# Altium schematic sheet P770010E05-Xx-SH-4_GNSS.SchDoc(P770010E05-Xx-SH-4_GNSS)
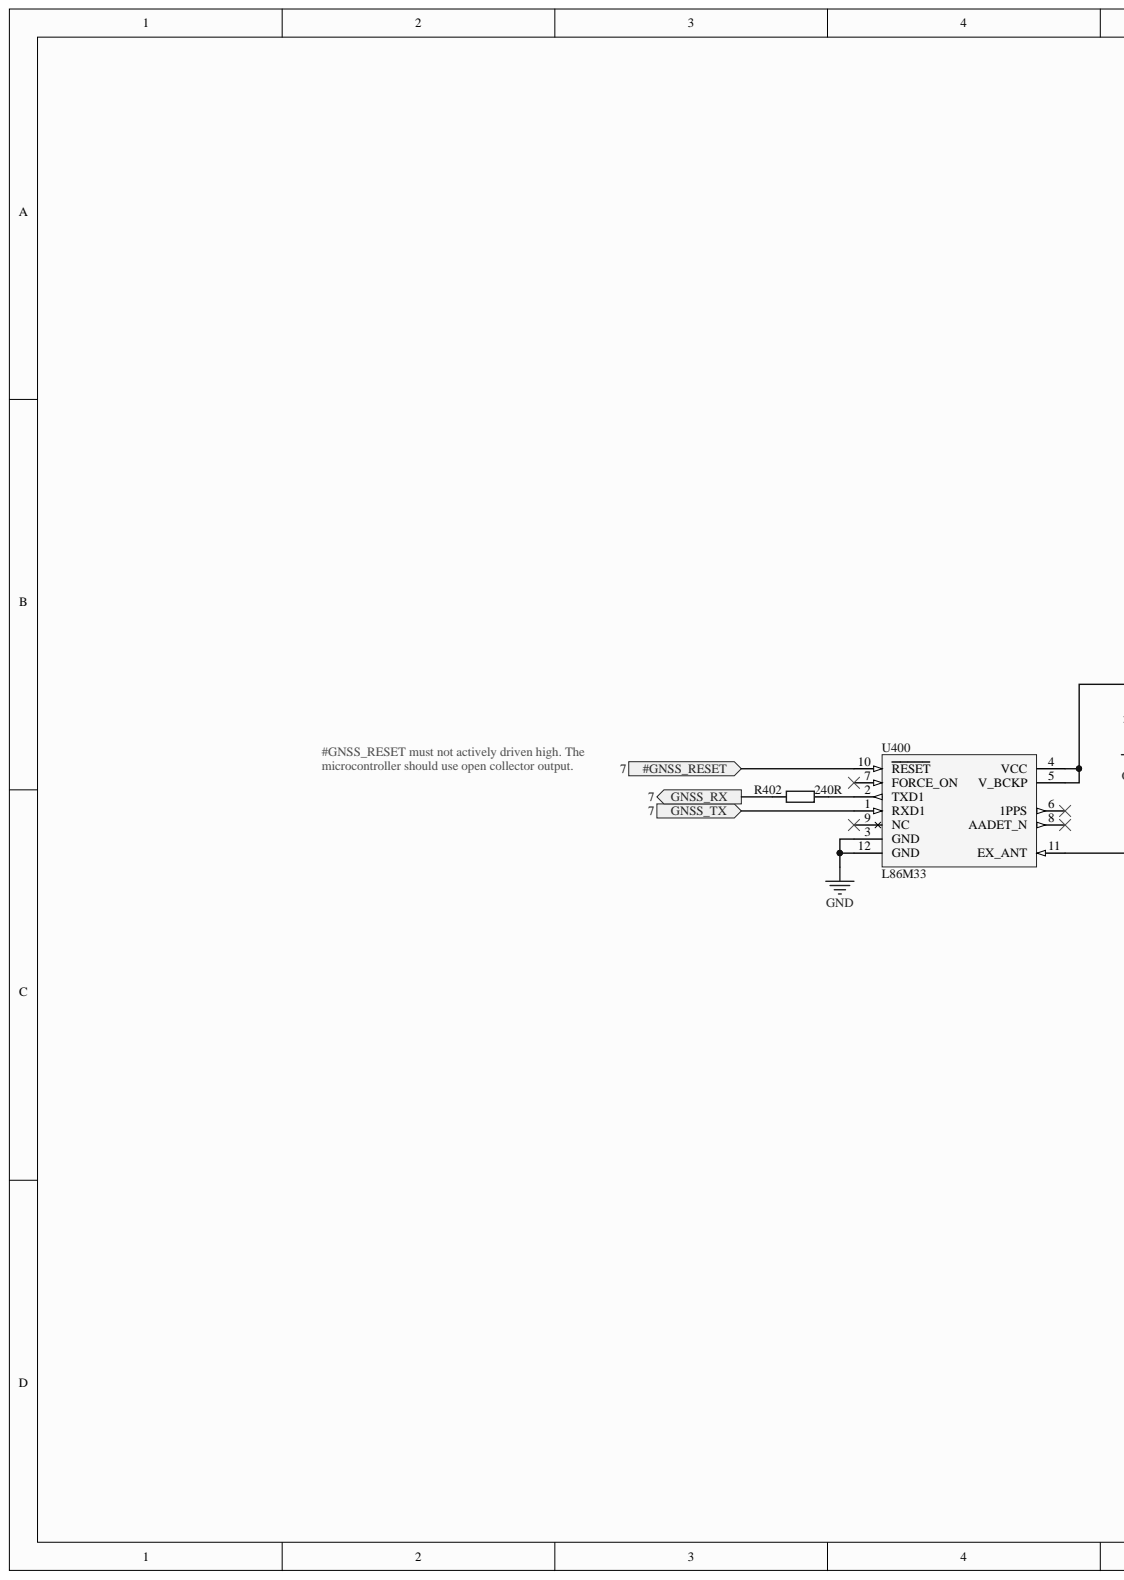
[diagram p0: P770010E05-Xx-SH-4_GNSS.SchDoc, left]
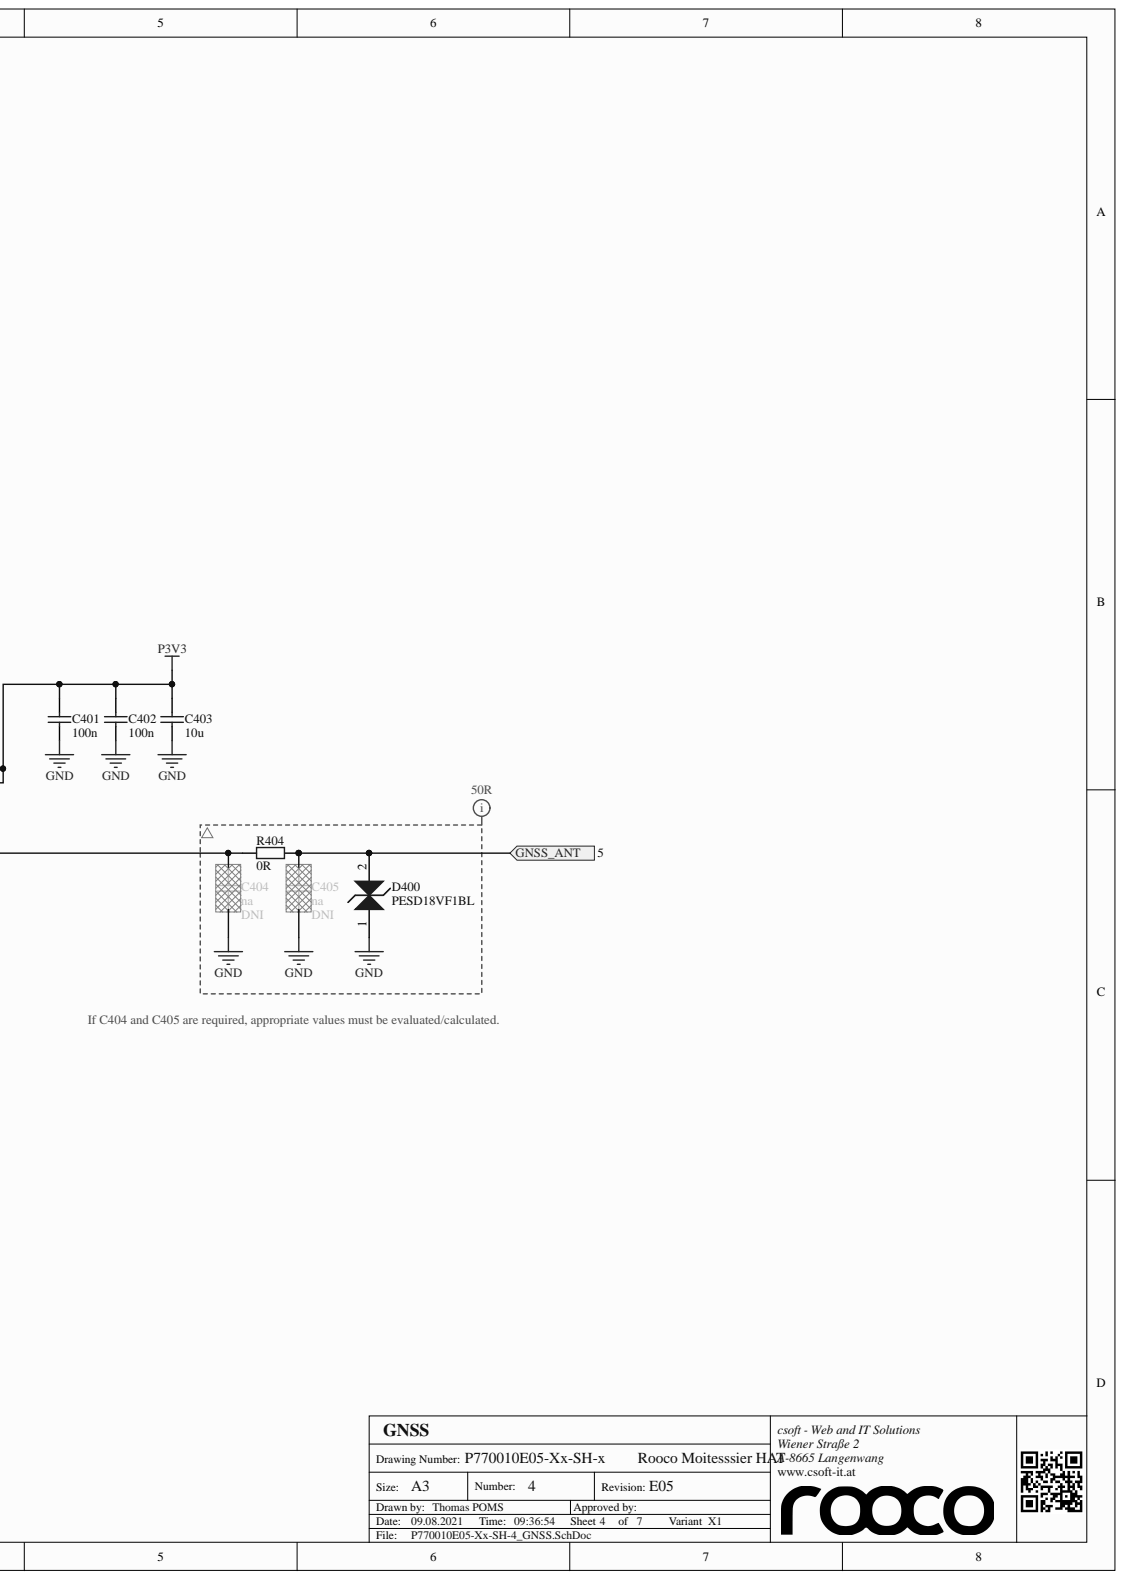
[diagram p1: P770010E05-Xx-SH-4_GNSS.SchDoc, right]

## Parts
C401  100n  [C0402]
C402  100n  [C0402]
C403  10u  [C0603]
C404  na  [C0402]
C405  na  [C0402]
D400  PESD18VF1BL  [PESD18VF1BL_SOD-882]
R402  240R  [R0402]
R404  0R  [R0402]
U400  L86­M33  [L86_M33]

## Ports
#GNSS_RESET, GNSS_ANT, GNSS_RX, GNSS_TX

## Nets
GND: C401.1 C402.1 C403.1 C404.1 C405.1 D400.1 U400.3(GND) U400.12(GND)
P3V3: C401.2 C402.2 C403.2 U400.4(VCC) U400.5(V_BCKP)
#GNSS_RESET: U400.10(RESET) ; port #GNSS_RESET
GNSS_ANT: C405.2 D400.2 R404.2 ; port GNSS_ANT
GNSS_RX: R402.1 ; port GNSS_RX
GNSS_TX: U400.1(RXD1) ; port GNSS_TX
NetC404_2: C404.2 R404.1 U400.11(EX_ANT)
NetR402_2: R402.2 U400.2(TXD1)
N00098:

Not connected: U400 6(1PPS) 7(FORCE_ON) 8(AADET_N) 9(NC)

## Library data of the parts
C401 C402 = C1005X7R1H104K050BB: ceramic chip capacitor, 100n, 50V, X7R, 0402; Manufacturer: TDK; ID: 1; Obsolete: False; Published by: FT-TEC; RevisionHistory: [1.0]; ToleranceValue: +/-10%; Component Kind: Standard; ComponentLink1Description: Datasheet; ComponentLink1URL: http://www.tdk.com/pdf/TDKMLCCCapRange.pdf; ComponentType: Standard; Database Table Name: Capacitor; Dielectric: X7R; Library Name: CompanyDatabase.DbLib; Package: 0402; Part Number: C1005X7R1H104K050BB; Pin Count: 2; Published: 16.02.2012; Revision: 1.0; TemperatureMax: 125°C; TemperatureMin: -55°C; Value: 100n; VoltageTypical: 50V; Checked by: Thomas POMS; Drawn by: Thomas POMS
C403 = GRM188R61C106KAALJ: ceramic chip capacitor, 10uF, 16V, X5R, 0603; Manufacturer: Murata; ID: 68; Obsolete: False; Published by: FT-TEC; RevisionHistory: [1.0] Creation; ToleranceValue: +/-10%; Component Kind: Standard; ComponentLink1Description: Datasheet; ComponentLink1URL: http://search.murata.co.jp/Ceramy/image/img/A01X/partnumbering_e_01.pdf; ComponentType: Standard; Database Table Name: Capacitor; Dielectric: X5R; Library Name: CompanyDatabase.DbLib; Package: 0603; Part Number: GRM188R61C106KAALJ; Pin Count: 2; Published: 03.09.2014; Revision: 1.0; TemperatureMax: 85°C; TemperatureMin: -55°C; Value: 10u; VoltageTypical: 16V; Checked by: Thomas POMS; Drawn by: Thomas POMS
C404 C405 = __C0402_NO_PART_NUMBER__: part not assembled, must be specified if required; Manufacturer: __NO_MANUFACTURER__; Database Table Name: Capacitor; ID: 64; Obsolete: False; Published by: FT-TEC; RevisionHistory: [1.0] Creation; Component Kind: Standard; ComponentType: Standard; DNI: DNI; Library Name: CompanyDatabase.DbLib; Package: 0402; Part Number: __C0402_NO_PART_NUMBER__; Pin Count: 2; Published: 24.03.2014; Revision: 1.0; TemperatureMax: 125°C; TemperatureMin: -55°C; Value: na; Checked by: Thomas POMS; Drawn by: Thomas POMS
D400 = PESD18VF1BL: ultra low capacitance bidrectional ESD protection diode; Manufacturer: NXP; ID: 24; Obsolete: False; Published by: FT-TEC; RevisionHistory: [1.0] Creation; Component Kind: Standard; ComponentLink1Description: Datasheet; ComponentLink1URL: http://www.nxp.com/documents/data_sheet/PESD18VF1BL.pdf; ComponentType: Standard; Database Table Name: Diode; Library Name: CompanyDatabase.DbLib; Package: SOD-882; Part Number: PESD18VF1BL; Pin Count: 2; Published: 22.07.2015; Revision: 1.0; TemperatureMax: 150°C; TemperatureMin: -55°C; Value: PESD18VF1BL; Checked by: Thomas POMS; Drawn by: Thomas POMS
R402 = CRCW0402240RFKED: thick film resistor, 240R, 1%, 100ppm,  0402; Manufacturer: Vishay; ID: 16; Obsolete: False; PowerRating: 63mW; Published by: FT-TEC; RevisionHistory: [1.0] Creation; VoltageRating: 50V; Component Kind: Standard; ComponentLink1Description: Datasheet; ComponentLink1URL: http://www.vishay.com/docs/20035/dcrcwe3.pdf; ComponentType: Standard; Database Table Name: Resistor; Library Name: CompanyDatabase.DbLib; Package: 0402; Part Number: CRCW0402240RFKED; Pin Count: 2; Published: 27.02.2012; Revision: 1.0; TemperatureCoefficient: 100ppm; TemperatureMax: 155°C; TemperatureMin: -55°C; ToleranceValue: 1%; Value: 240R; Checked by: Thomas POMS; Drawn by: Thomas Poms
R404 = CRCW04020000Z0ED: thick film resistor, 0R, 1%, 100ppm, 0402; Manufacturer: Vishay; ID: 2; Obsolete: False; PowerRating: 63mW; Published by: FT-TEC; RevisionHistory: [1.0] Creation; VoltageRating: 50V; Component Kind: Standard; ComponentType: Standard; Database Table Name: Resistor; Library Name: CompanyDatabase.DbLib; Package: 0402; Part Number: CRCW04020000Z0ED; Pin Count: 2; Published: 16.02.2012; Revision: 1.0; TemperatureCoefficient: 100ppm; TemperatureMax: 155°C; TemperatureMin: -55°C; ToleranceValue: 1%; Value: 0R; Checked by: Thomas POMS; Drawn by: Thomas Poms
U400 = L86­M33: GPS/GNSS antenna module supporting parallel GPS/GLONASS; Manufacturer: Quectel; Database Table Name: GPS; ID: 3; Obsolete: False; Published by: FT-TEC; RevisionHistory: [1.0] Creation; VoltageMax: 4.3V; VoltageMin: 3.0V; VoltageTypical: 3.3V; Component Kind: Standard; ComponentLink1Description: Documentation; ComponentLink1URL: http://www.quectel.com/product/prodetail.aspx?id=77; ComponentType: Standard; Library Name: CompanyDatabase.DbLib; Package: 18.4x18.4mm; Part Number: L86­M33; Pin Count: 12; Published: 26.07.2015; Revision: 1.0; TemperatureMax: 85°C; TemperatureMin: -40°C; Value: L86­M33; Checked by: Thomas POMS; Drawn by: Thomas POMS
